# Altium schematic sheet qsdqse.SchDoc(qsdqse)
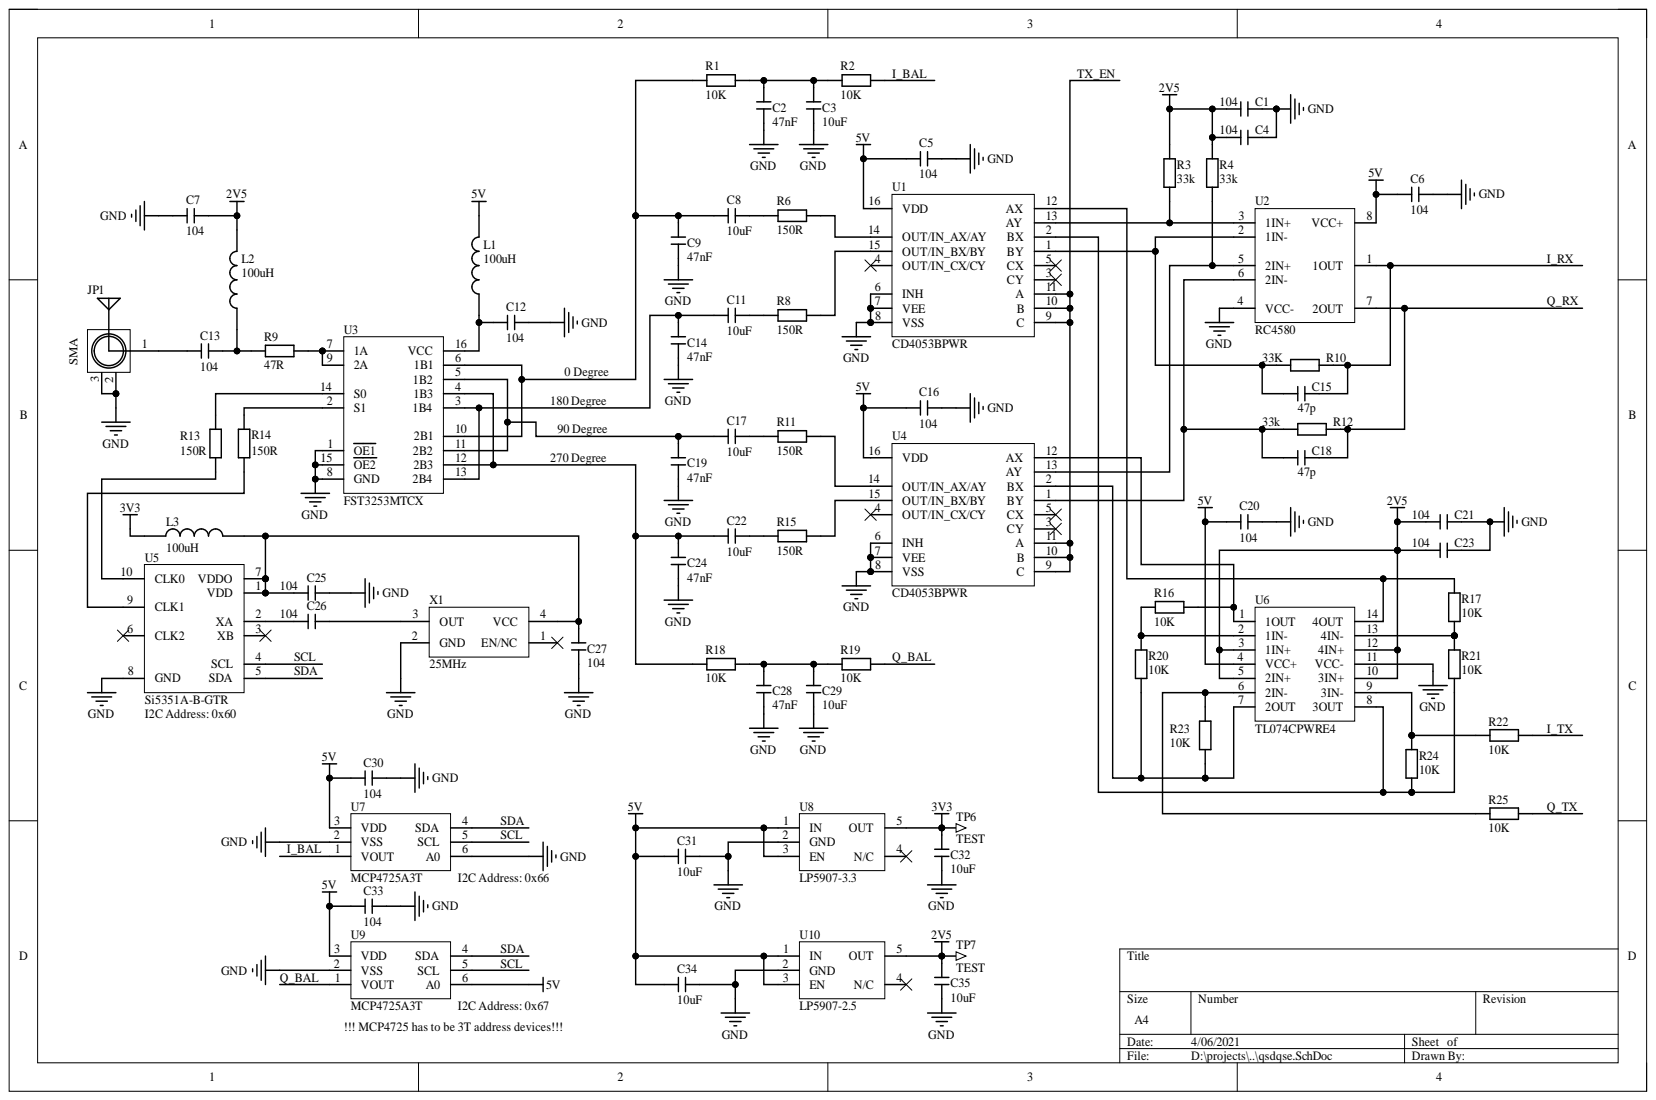
[diagram p0: qsdqse.SchDoc]

## Parts
C1  104  [C 0603_L]
C2  47nF  [C 0603_L]
C3  10uF  [C 0603_L]
C4  104  [C 0603_L]
C5  104  [C 0603_L]
C6  104  [C 0603_L]
C7  104  [C 0603_L]
C8  10uF  [C 0603_L]
C9  47nF  [C 0603_L]
C11  10uF  [C 0603_L]
C12  104  [C 0603_L]
C13  104  [C 0603_L]
C14  47nF  [C 0603_L]
C15  47p  [C 0603_L]
C16  104  [C 0603_L]
C17  10uF  [C 0603_L]
C18  47p  [C 0603_L]
C19  47nF  [C 0603_L]
C20  104  [C 0603_L]
C21  104  [C 0603_L]
C22  10uF  [C 0603_L]
C23  104  [C 0603_L]
C24  47nF  [C 0603_L]
C25  104  [C 0603_L]
C26  104  [C 0603_L]
C27  104  [C 0603_L]
C28  47nF  [C 0603_L]
C29  10uF  [C 0603_L]
C30  104  [C 0603_L]
C31  10uF  [C 0603_L]
C32  10uF  [C 0603_L]
C33  104  [C 0603_L]
C34  10uF  [C 0603_L]
C35  10uF  [C 0603_L]
JP1  SMA  [SMA_ANGEL]
L1  100uH  [L 0603]
L2  100uH  [L 0603]
L3  100uH  [L 0603]
R1  10K  [R 0603_L]
R2  10K  [R 0603_L]
R3  33k  [R 0603_L]
R4  33k  [R 0603_L]
R6  150R  [R 0603_L]
R8  150R  [R 0603_L]
R9  47R  [R 0603_L]
R10  33K  [R 0603_L]
R11  150R  [R 0603_L]
R12  33k  [R 0603_L]
R13  150R  [R 0603_L]
R14  150R  [R 0603_L]
R15  150R  [R 0603_L]
R16  10K  [R 0603_L]
R17  10K  [R 0603_L]
R18  10K  [R 0603_L]
R19  10K  [R 0603_L]
R20  10K  [R 0603_L]
R21  10K  [R 0603_L]
R22  10K  [R 0603_L]
R23  10K  [R 0603_L]
R24  10K  [R 0603_L]
R25  10K  [R 0603_L]
TP6  TEST  [TEST 1.6]
TP7  TEST  [TEST 1.6]
U1  CD4053BPWR  [SSOP16_L]
U2  RC4580  [SSOP8_L]
U3  FST3253MTCX  [SSOP16_L]
U4  CD4053BPWR  [SSOP16_L]
U5  Si5351A-B-GTR  [MSOP10_L]
U6  TL074CPWRE4  [SSOP14_L]
U7  MCP4725A3T  [SOT23-6L]
U8  LP5907-3.3  [SOT23-5N]
U9  MCP4725A3T  [SOT23-6L]
U10  LP5907-2.5  [SOT23-5N]
X1  25MHz  [OSC 3225-4P]

## Nets
2V5: C1.1 C4.1 C7.2 C21.1 C23.1 C35.1 L2.2 R3.2 R4.2 TP7.1 U6.3(1IN+) U6.5(2IN+) U6.10(3IN+) U6.12(4IN+) U10.5(OUT)
3V3: C32.1 L3.1 TP6.1 U8.5(OUT)
5V: C5.1 C6.1 C16.1 C20.1 C30.1 C31.2 C33.1 C34.2 L1.2 U1.16(VDD) U2.8(VCC+) U4.16(VDD) U6.4(VCC+) U7.3(VDD) U8.1(IN) U8.3(EN) U9.3(VDD) U9.6(A0) U10.1(IN) U10.3(EN)
GND: C1.2 C2.1 C3.1 C4.2 C5.2 C6.2 C7.1 C9.1 C12.1 C14.1 C16.2 C19.1 C20.2 C21.2 C23.2 C24.1 C25.1 C27.1 C28.1 C29.1 C30.2 C31.1 C32.2 C33.2 C34.1 C35.2 JP1.2 JP1.3 U1.6(INH) U1.7(VEE) U1.8(VSS) U2.4(VCC-) U3.1(OE1) U3.8(GND) U3.15(OE2) U4.6(INH) U4.7(VEE) U4.8(VSS) U5.8(GND) U6.11(VCC-) U7.2(VSS) U7.6(A0) U8.2(GND) U9.2(VSS) U10.2(GND) X1.2(GND)
I_BAL: R2.2 U7.1(VOUT)
I_RX: C15.2 R10.1 U2.1(1OUT)
I_TX: R22.1
Q_BAL: R19.2 U9.1(VOUT)
Q_RX: C18.2 R12.1 U2.7(2OUT)
Q_TX: R25.2
SCL: U5.4(SCL) U7.5(SCL) U9.5(SCL)
SDA: U5.5(SDA) U7.4(SDA) U9.4(SDA)
TX_EN: U1.9(C) U1.10(B) U1.11(A) U4.9(C) U4.10(B) U4.11(A)
NetC2_2: C2.2 C3.2 R1.2 R2.1
NetC8_1: C8.1 R6.1
NetC8_2: C8.2 C9.2 R1.1 U3.6(1B1) U3.10(2B1)
NetC11_1: C11.1 R8.1
NetC11_2: C11.2 C14.2 U3.3(1B4) U3.13(2B4)
NetC12_2: C12.2 L1.1 U3.16(VCC)
NetC13_1: C13.1 JP1.1
NetC13_2: C13.2 L2.1 R9.1
NetC15_1: C15.1 R10.2 U1.1(BY) U2.2(1IN-)
NetC17_1: C17.1 R11.1
NetC17_2: C17.2 C19.2 U3.5(1B2) U3.11(2B2)
NetC18_1: C18.1 R12.2 U2.6(2IN-) U4.1(BY)
NetC22_1: C22.1 R15.1
NetC22_2: C22.2 C24.2 R18.1 U3.4(1B3) U3.12(2B3)
NetC25_2: C25.2 C27.2 L3.2 U5.1(VDD) U5.7(VDDO) X1.4(VCC)
NetC26_1: C26.1 X1.3(OUT)
NetC26_2: C26.2 U5.2(XA)
NetC28_2: C28.2 C29.2 R18.2 R19.1
NetR3_1: R3.1 U1.13(AY) U2.3(1IN+)
NetR4_1: R4.1 U2.5(2IN+) U4.13(AY)
NetR6_2: R6.2 U1.14(OUT/IN_AX/AY)
NetR8_2: R8.2 U1.15(OUT/IN_BX/BY)
NetR9_2: R9.2 U3.7(1A) U3.9(2A)
NetR11_2: R11.2 U4.14(OUT/IN_AX/AY)
NetR13_1: R13.1 U5.10(CLK0)
NetR13_2: R13.2 U3.14(S0)
NetR14_1: R14.1 U5.9(CLK1)
NetR14_2: R14.2 U3.2(S1)
NetR15_2: R15.2 U4.15(OUT/IN_BX/BY)
NetR16_1: R16.1 R20.2 U6.2(1IN-)
NetR16_2: R16.2 U4.12(AX) U6.1(1OUT)
NetR17_1: R17.1 R21.2 U6.13(4IN-)
NetR17_2: R17.2 U1.12(AX) U6.14(4OUT)
NetR20_1: R20.1 R23.1 U4.2(BX) U6.7(2OUT)
NetR21_1: R21.1 R24.1 U1.2(BX) U6.8(3OUT)
NetR22_2: R22.2 R24.2 U6.9(3IN-)
NetR23_2: R23.2 R25.1 U6.6(2IN-)

Not connected: U1 3(CY) 4(OUT/IN_CX/CY) 5(CX); U4 3(CY) 4(OUT/IN_CX/CY) 5(CX); U5 3(XB) 6(CLK2); U8 4(N/C); U10 4(N/C); X1 1(EN/NC)

## Library data of the parts
C1-C9 C11-C35 = C: 无极性贴片电容; 版权归属: 源创客; BOM名称: 无极性贴片电容; Component Kind: Standard; Library Name: 3.1   - 电阻-电容-电感.SchLib; 学习资源: www.ooocke.com
JP1 = SMA: SMA-2.4G射频天线接口; 版权归属: 源创客; BOM名称: SMA-2.4G射频天线接口; Component Kind: Standard; Library Name: 4.1   - 接口类.SchLib; 学习资源: www.ooocke.com
L1-L3 = L: 小功率贴片电感; 版权归属: 源创客; BOM名称: 小功率贴片电感; Component Kind: Standard; Library Name: 3.1   - 电阻-电容-电感.SchLib; 学习资源: www.ooocke.com
R1-R4 R6 R8-R25 = R: 贴片电阻; 版权归属: 源创客; BOM名称: 贴片电阻; Component Kind: Standard; Library Name: 3.1   - 电阻-电容-电感.SchLib; 学习资源: www.ooocke.com
TP6 TP7 = TEST: 测试点; 版权归属: 源创客; BOM名称: 测试点; Component Kind: Standard; Library Name: 15.1 - 未分类器件.SchLib; 学习资源: www.ooocke.com
U1 U4 = CD4053BPWR: CD405xB CMOS Single 8-Channel Analog Multiplexer/Demultiplexer with Logic-Level Conversion; Manufacturer_Name: Texas Instruments; Component Kind: Standard; Datasheet Link: http://www.ti.com/lit/gpn/cd4053b; Height: 1.2mm; Library Name: Schlib.SchLib; Manufacturer_Part_Number: CD4053BPWR; Mouser2 Part Number: 595-CD4053BPWR; Mouser2 Price/Stock: https://www.mouser.com/Search/Refine.aspx?Keyword=595-CD4053BPWR
U2 = TL072CPWR: Low-Noise Operational Amplifiers; Manufacturer_Name: Texas Instruments; Component Kind: Standard; Datasheet Link: http://www.ti.com/lit/gpn/tl072; Height: 1.2mm; Library Name: Schlib.SchLib; Manufacturer_Part_Number: TL072CPWR; Mouser2 Part Number: 595-TL072CPWR; Mouser2 Price/Stock: https://www.mouser.com/Search/Refine.aspx?Keyword=595-TL072CPWR
U3 = FST3253MTCX: Encoders, Decoders, Multiplexers & Demultiplexers; Manufacturer_Name: ON Semiconductor; Component Kind: Standard; Datasheet Link: https://componentsearchengine.com//FST3253MTCX.pdf; Height: 1.1mm; Library Name: Schlib.SchLib; Manufacturer_Part_Number: FST3253MTCX; Mouser2 Part Number: 512-FST3253MTCX; Mouser2 Price/Stock: https://www.mouser.com/Search/Refine.aspx?Keyword=512-FST3253MTCX
U5 = Si5351A-B-GTR: I2C-PROGRAMMABLE ANY-FREQUENCY CMOS CLOCK  GENERATOR + VCXO; Manufacturer_Name: Silicon Labs; Component Kind: Standard; Datasheet Link: https://componentsearchengine.com//SI5351A-B-GTR.pdf; Height: 1.1mm; Library Name: Schlib.SchLib; Manufacturer_Part_Number: Si5351A-B-GTR; Mouser2 Part Number: 634-SI5351A-B-GTR; Mouser2 Price/Stock: https://www.mouser.com/Search/Refine.aspx?Keyword=634-SI5351A-B-GTR
U6 = TL074CPWRE4: TL07xx Low-Noise FET-Input Operational Amplifiers; Manufacturer_Name: Texas Instruments; Component Kind: Standard; Datasheet Link: https://www.arrow.com/en/products/tl074cpwre4/texas-instruments; Height: 1.2mm; Library Name: Schlib.SchLib; Manufacturer_Part_Number: TL074CPWRE4; Mouser2 Part Number: 595-TL074CPWRE4; Mouser2 Price/Stock: https://www.mouser.com/Search/Refine.aspx?Keyword=595-TL074CPWRE4
U7 U9 = MCP4725A3T: 12-Bit Digital-to-Analog Converter with EEPROM Memory in SOT-23-6; Manufacturer_Name: Microchip; Manufacturer_Part_Number: MCP4725A0T-E/CHVAO; Component Kind: Standard; Datasheet Link: http://ww1.microchip.com/downloads/en/devicedoc/22039d.pdf; Height: 1.45mm; Library Name: Schlib.SchLib
U8 = LP5907-3.3: Ultra-Low-Noise, Low-IQ LDO; Component Kind: Standard; Library Name: Schlib.SchLib
U10 = LP5907-2.5: Ultra-Low-Noise, Low-IQ LDO; Component Kind: Standard; Library Name: Schlib.SchLib
X1 = XTAL-ACT-4P: 4脚有源晶振; 版权归属: 源创客; BOM名称: 4脚有源晶振; Component Kind: Standard; Library Name: 10.1 - 保险丝-晶振.SchLib; 学习资源: www.ooocke.com
